# Altium schematic sheet Music.SchDoc(Music)
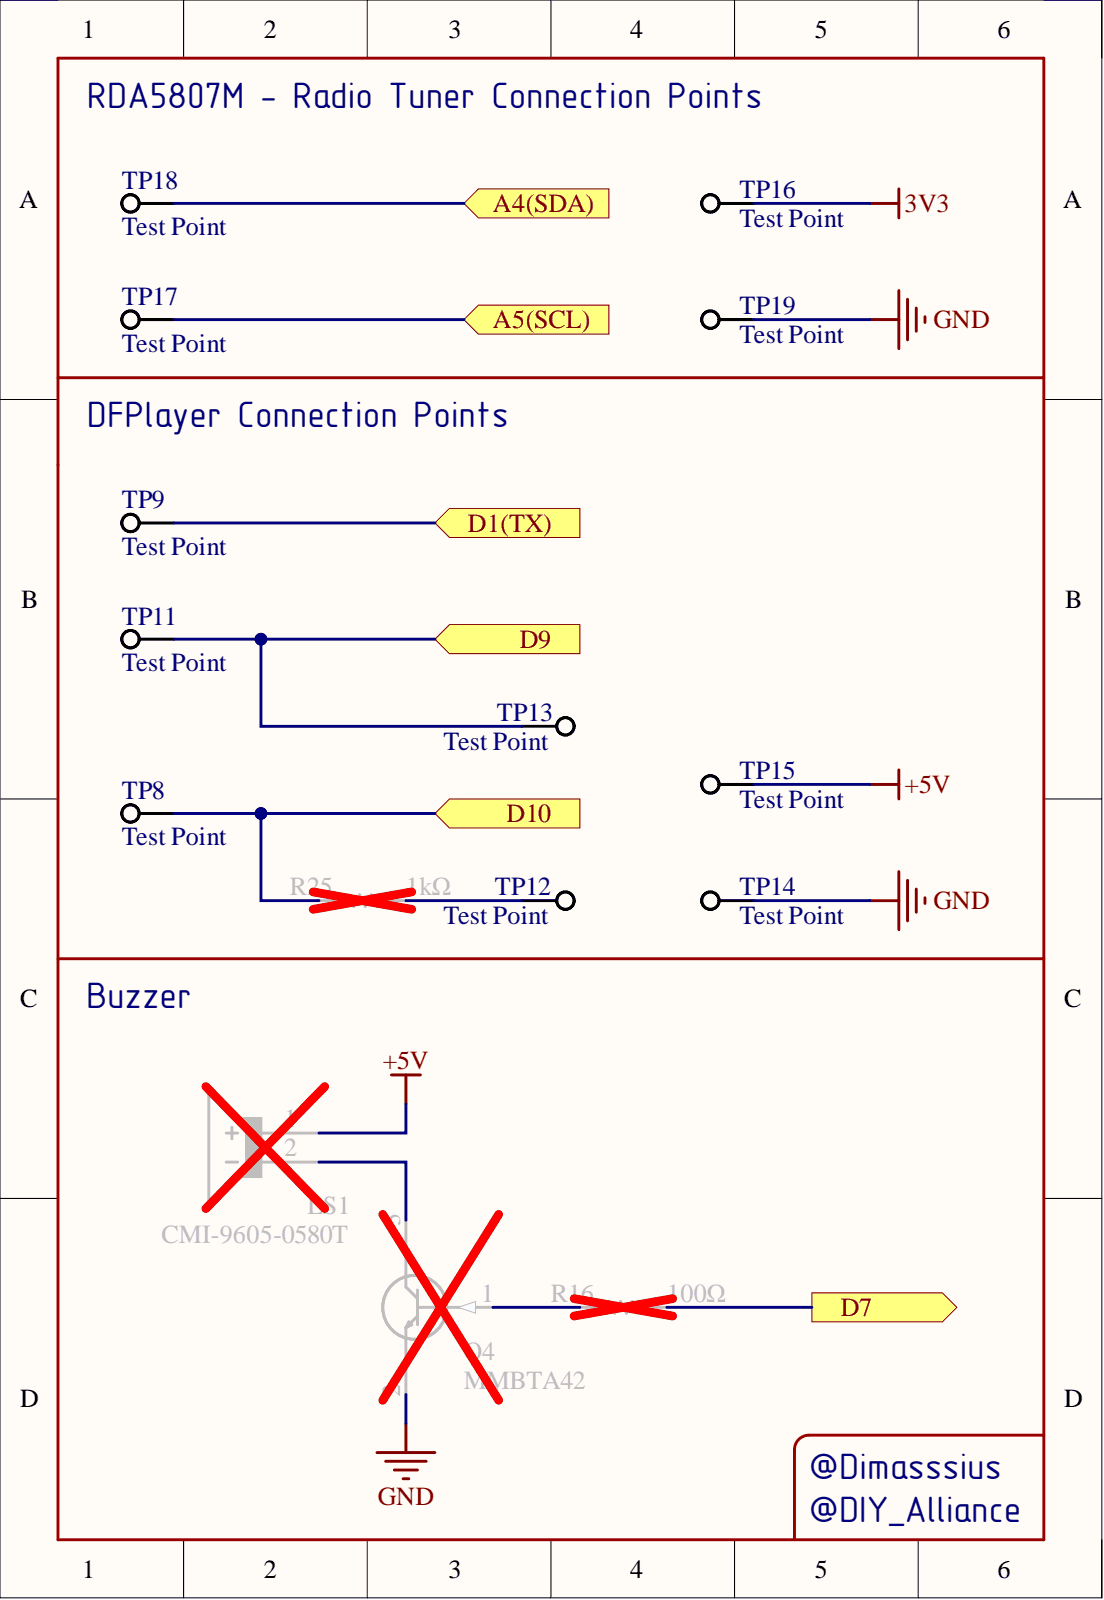
[diagram p0: Music.SchDoc]

## Parts
LS1  CMI-9605-0580T  [CMI96050580T]
Q4  MMBTA42  [SOT105P255X140-3N]
R16  100Ω  [RES_SMD_R0603_(M1608)_R0402A_(M1005A)]
R25  1kΩ  [RES_SMD_R0603_(M1608)_R0402A_(M1005A)]
TP8  Test Point  [Test Point]  (no BOM)
TP9  Test Point  [Test Point]  (no BOM)
TP11  Test Point  [Test Point]  (no BOM)
TP12  Test Point  [Test Point]  (no BOM)
TP13  Test Point  [Test Point]  (no BOM)
TP14  Test Point  [Test Point]  (no BOM)
TP15  Test Point  [Test Point]  (no BOM)
TP16  Test Point  [Test Point]  (no BOM)
TP17  Test Point  [Test Point]  (no BOM)
TP18  Test Point  [Test Point]  (no BOM)
TP19  Test Point  [Test Point]  (no BOM)

## Ports
A4(SDA), A5(SCL), D1(TX), D7, D9, D10

## Nets
3V3: TP16.1
+5V: LS1.1 TP15.1
GND: Q4.2 TP14.1 TP19.1
A4(SDA): TP18.1 ; port A4(SDA)
A5(SCL): TP17.1 ; port A5(SCL)
D1(TX): TP9.1 ; port D1(TX)
D7: R16.2 ; port D7
D9: TP11.1 TP13.1 ; port D9
D10: R25.1 TP8.1 ; port D10
NetLS1_2: LS1.2 Q4.3
NetQ4_1: Q4.1 R16.1
NetR25_2: R25.2 TP12.1

## Library data of the parts
LS1 = CMI-9605-0580T: Buzzer, D=9.6mm, 80dB, Active (Built-in Driver Circuit, Electromagnetic Type, 2.7kHz, Plugin, Buzzers, ROHS; Component Kind: Standard; Datasheet Link: https://componentsearchengine.com/Datasheets/1/CMI-9605-0580T.pdf; Height: 5mm; Library Name: SamacSys.SchLib; Value: CMI-9605-0580T
Q4 = MMBTA42: Transistor Bipolar (BJT), NPN, SOT-23, 300V, 225mW, 25@10mA, 10V, 500mA, NPN Bipolar (BJT), ROHS; Component Kind: Standard; Datasheet Link: http://www.st.com/web/en/resource/technical/document/datasheet/CD00002980.pdf; Height: 1.4mm; Library Name: SamacSys.SchLib; Value: MMBTA42
R16 = RES_SMD_100Ω: Resistor, Thick Film Resistor, SMD, 100Ω, ±5%, Chip Resistor - Surface Mount, ROHS; Component Kind: Standard; Library Name: Resistors.SchLib; Value: 100Ω
R25 = RES_SMD_1KΩ: Resistor, Thick Film Resistor, SMD, 1kΩ, ±5%, Chip Resistor - Surface Mount, ROHS; Component Kind: Standard; Library Name: Resistors.SchLib; Value: 1kΩ
TP8 TP9 TP11-TP19 = Test Point: Description: Test Point; Library Name: SamacSys.SchLib; Value: Test Point
